# Altium schematic sheet Monitoring.SchDoc
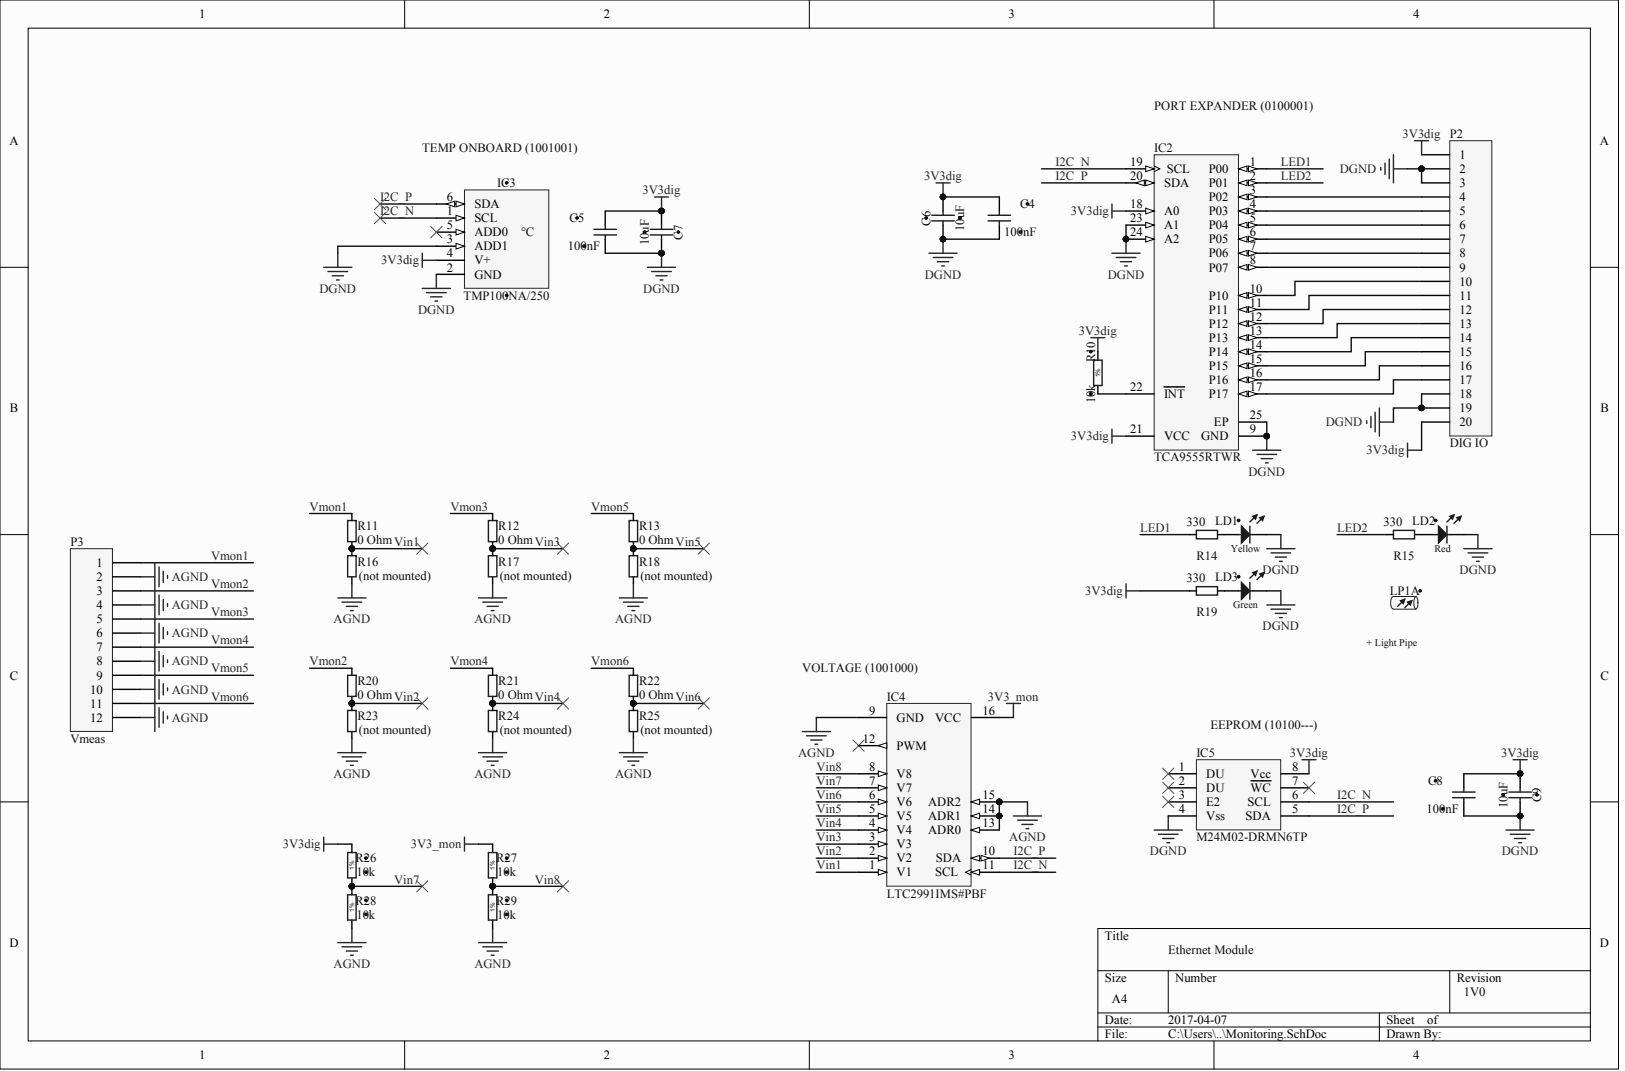
[diagram p0: Monitoring.SchDoc]

## Parts
C4  100nF  [CAPC1005X55N]
C5  100nF  [CAPC1005X55N]
C6  10uF  [CAPC1608X87N]
C7  10uF  [CAPC1608X87N]
C8  100nF  [CAPC1005X55N]
C9  10uF  [CAPC1608X87N]
IC2  TCA9555RTWR
IC3  TMP100NA/250  [SOT95P280X145-6N]
IC4  LTC2991IMS#PBF
IC5  M24M02-DRMN6TP
LD1  Yellow  [LED_DIALIGHT_597-3401-507F]
LD2  Red  [LED_DIALIGHT_597-3111-507F]
LD3  Green  [LED_DIALIGHT_597-3301-507F]
LP1A  =Color  [LEDLP_DIALIGHT_515-1064F]
P2  DIG IO
P3  Vmeas
R10  10k  [RESC1005X40N]
R11  Resistance (Ohms): 0 Ohm  (hidden comment: ERJ-2RKF2201X)
R12  Resistance (Ohms): 0 Ohm  (hidden comment: ERJ-2RKF2201X)
R13  Resistance (Ohms): 0 Ohm  (hidden comment: ERJ-2RKF2201X)
R14  ERJ-2RKF2201X  (value not drawn)
R15  ERJ-2RKF2201X  (value not drawn)
R16  ERJ-2RKF2201X  (value not drawn)
R17  ERJ-2RKF2201X  (value not drawn)
R18  ERJ-2RKF2201X  (value not drawn)
R19  ERJ-2RKF2201X  (value not drawn)
R20  Resistance (Ohms): 0 Ohm  (hidden comment: ERJ-2RKF2201X)
R21  Resistance (Ohms): 0 Ohm  (hidden comment: ERJ-2RKF2201X)
R22  Resistance (Ohms): 0 Ohm  (hidden comment: ERJ-2RKF2201X)
R23  ERJ-2RKF2201X  (value not drawn)
R24  ERJ-2RKF2201X  (value not drawn)
R25  ERJ-2RKF2201X  (value not drawn)
R26  10k  [RESC1005X40N]
R27  10k  [RESC1005X40N]
R28  10k  [RESC1005X40N]
R29  10k  [RESC1005X40N]

## Nets
3V3_MON: IC4.16(VCC) R27.2
3V3DIG: C4.2 C5.2 C6.2 C7.2 C8.2 C9.2 IC2.18(A0) IC2.21(VCC) IC3.4(V+) IC5.8(Vcc) P2.1 P2.20 R10.2 R19.1 R26.2
AGND: IC4.9(GND) IC4.13(ADR0) IC4.14(ADR1) IC4.15(ADR2) P3.2 P3.4 P3.6 P3.8 P3.10 P3.12 R16.2 R17.2 R18.2 R23.2 R24.2 R25.2 R28.1 R29.1
DGND: C4.1 C5.1 C6.1 C7.1 C8.1 C9.1 IC2.9(GND) IC2.23(A1) IC2.24(A2) IC2.25(EP) IC3.2(GND) IC3.3(ADD1) IC5.4(Vss) LD1.1 LD2.1 LD3.1 P2.2 P2.3 P2.18 P2.19
I2C_N: IC2.19(SCL) IC3.1(SCL) IC4.11(SCL) IC5.6(SCL)
I2C_P: IC2.20(SDA) IC3.6(SDA) IC4.10(SDA) IC5.5(SDA)
LED1: IC2.1(P00) R14.1
LED2: IC2.2(P01) R15.1
VIN1: IC4.1(V1) R11.2 R16.1
VIN2: IC4.2(V2) R20.2 R23.1
VIN3: IC4.3(V3) R12.2 R17.1
VIN4: IC4.4(V4) R21.2 R24.1
VIN5: IC4.5(V5) R13.2 R18.1
VIN6: IC4.6(V6) R22.2 R25.1
VIN7: IC4.7(V7) R26.1 R28.2
VIN8: IC4.8(V8) R27.1 R29.2
VMON1: P3.1 R11.1
VMON2: P3.3 R20.1
VMON3: P3.5 R12.1
VMON4: P3.7 R21.1
VMON5: P3.9 R13.1
VMON6: P3.11 R22.1
NetIC2_3: IC2.3(P02) P2.4
NetIC2_4: IC2.4(P03) P2.5
NetIC2_5: IC2.5(P04) P2.6
NetIC2_6: IC2.6(P05) P2.7
NetIC2_7: IC2.7(P06) P2.8
NetIC2_8: IC2.8(P07) P2.9
NetIC2_10: IC2.10(P10) P2.10
NetIC2_11: IC2.11(P11) P2.11
NetIC2_12: IC2.12(P12) P2.12
NetIC2_13: IC2.13(P13) P2.13
NetIC2_14: IC2.14(P14) P2.14
NetIC2_15: IC2.15(P15) P2.15
NetIC2_16: IC2.16(P16) P2.16
NetIC2_17: IC2.17(P17) P2.17
NetIC2_22: IC2.22(INT) R10.1
NetLD1_2: LD1.2 R14.2
NetLD2_2: LD2.2 R15.2
NetLD3_2: LD3.2 R19.2

Not connected: IC3 5(ADD0); IC4 12(PWM); IC5 1(DU) 2(DU) 3(E2) 7(WC)

## Library data of the parts
C4 C5 C8 = (no symbol name): Part Number: CC0402_100NF_16V_10%_X7R; Part Description: SMD Multilayer Chip Ceramic Capacitor; Manufacturer: GENERIC; Manufacturer1 Part Number: GRM155R71C104KA88D; Voltage: 16V; TC: X7R; Tolerance: ±10%; Table: Capacitors SMD; Component Kind: Standard; Component Type: Standard; Pin Count: 2; Value: 100nF; Manufacturer Part Number: CC0402_100NF_16V_10%_X7R; Case: 0402; Mounted: Yes; Socket: No; SMD: Yes; Manufacturer1 Example: MURATA; Manufacturer1 ComponentHeight: 0.55mm; HelpURL: \\cern.ch\dfs\Applications\Altium\Datasheets\CC0402_X7R_MURATA_GRM.pdf; CreateDate: 05/12/2013; LatestRevisionDate: 03/12/2007; DigiKey part no.: 490-3261-1-ND; Author: CERN DEM JLC
C6 C7 C9 = (no symbol name): Part Number: CC0603_10UF_6.3V_20%_X5R; Part Description: SMD Multilayer Chip Ceramic Capacitor; Manufacturer: GENERIC; Manufacturer Part Number: C0603C106M9PACTU; Voltage: 6.3V; TC: X5R; Tolerance: ±20%; Table: Capacitors SMD; Component Kind: Standard; Component Type: Standard; Pin Count: 2; Value: 10uF; Case: 0603; Mounted: Yes; Socket: No; SMD: Yes; Manufacturer1 Example: KEMET; Manufacturer1 ComponentHeight: 0.95mm; HelpURL: \\cern.ch\dfs\Applications\Altium\Datasheets\CC0603_X5R_KEMET.pdf; CreateDate: 03/12/2007; LatestRevisionDate: 03/12/2007; DigiKey part no.: 399-5504-1-ND; Author: CERN DEM JLC
IC2 = (no symbol name): Manufacturer: Texas Instruments; Eco-Plan: Green (RoHS & no Sb/Br); SubFamily: I2C IO Expander; Interrupt Output: Yes; Max Frequency(kHz): 400; I2C Address: 0100 xxx; # of I/Os: 16; Vcc range(V): 1.65 to 5.5; DatasheetVersion: SCPS200A; PackageVersion: Jul-2004; PackageDescription: 24-Lead Plastic Quad FlatPack, No-Lead, 4x4x0.8mm Body [WQFN]; Supplier 1: Digi-Key; Supplier Part Number 1: 296-24857-2-ND; Supplier 2: Digi-Key; Supplier Part Number 2: 296-24857-1-ND; Supplier 3: Digi-Key; Supplier Part Number 3: 296-24857-6-ND; Supplier 4: Newark; Supplier Part Number 4: 24R9979; Supplier 5: Mouser; Supplier Part Number 5: 595-TCA9555RTWR; Configuration Registers: Yes; 5V Tolerant I/O: Yes; Push-Pull I/O Type: Yes; Mounting Technology: Surface Mount; PartNumber: TCA9555RTWR; Code_JEDEC: MO-220; ComponentLink1Description: Datasheet; ComponentLink1URL: http://www.ti.com/general/docs/lit/getliterature.tsp?genericPartNumber=TCA9555&fileType=pdf; DigiKey part no.: 296-24857-2-ND; Manufacturer part no.: TCA9555RTWR
IC3 = (no symbol name): Part Description: -55°C to +125°C, ±3.0°C  Accuracy, Digital Temperature Sensor with I2C Interface; Manufacturer: TEXAS INSTRUMENTS; Table: Sensors; Part Number: TMP100NA/250; Component Kind: Standard; Component Type: Standard; Device: TMP100NA/250; PackageDescription: SOT23, 6-Leads, Body 1.6x3.0mm, Pitch 0.95mm, IPC Medium Density; Manufacturer Part Number: TMP100NA/250; Pin Count: 6; Case: SOT23-6; Mounted: Yes; Socket: No; SMD: Yes; ComponentHeight: 1.45mm; HelpURL: \\cern.ch\dfs\Applications\Altium\Datasheets\TMP100NA-250.pdf; CreateDate: 29/10/2012; LatestRevisionDate: 29/10/2012; DigiKey part no.: 296-12749-1-ND; Author: CERN DEM JLC
IC4 = (no symbol name): Manufacturer: Linear Technology; DatasheetVersion: Rev. B; PackageVersion: Rev. Ø, 06/2010; PackageReference: MS16; PackageDescription: 16-Pin Plastic MSOP, Body 4.04 x 3.00 mm, Pitch 0.50 mm; Supplier 1: Digi-Key; Supplier Part Number 1: LTC2991IMS#PBF-ND; Supplier 2: Farnell; Supplier Part Number 2: 2308601; Supplier 3: Newark; Supplier Part Number 3: 59T5577; RoHS: TRUE; Mounting Technology: Surface Mount; PartNumber: LTC2991IMS#PBF; ComponentLink1Description: Manufacturer URL; ComponentLink1URL: http://www.linear.com/; ComponentLink2Description: Datasheet; ComponentLink2URL: http://cds.linear.com/docs/en/datasheet/2991fb.pdf; ComponentLink3Description: Package Specification; ComponentLink3URL: http://cds.linear.com/docs/en/packaging/05081669_A_MS16.pdf; DigiKey part no.: LTC2991IMS#PBF-ND
IC5 = (no symbol name): Manufacturer: STMicroelectronics; Format - Memory: EEPROMs - Serial; Memory Type: EEPROM; Memory Size: 2M (256K x 8); Speed: 100kHz, 400kHz, 1MHz; Interface: I²C, 2-Wire Serial; Voltage - Supply: 1.8 V ~ 5.5 V; Supplier 1: Digi-Key; Manufacturer Part Number: M24M02-DRMN6TP; RoHS: RoHS Compliant; Category: Integrated Circuits (ICs); Stock 1: 5255; Pricing 1: 1=2.46, 10=2.211, 25=2.1756, 50=2.1692, 100=1.938, 250=1.87548, 500=1.86808, 1000=1.73979 (EUR); Packaging: Cut Tape (CT); Operating Temperature: -40°C ~ 85°C (TA); Package / Case: 8-SOIC (0.154", 3.90mm Width); Supplier Device Package: 8-SO; ComponentLink1URL: http://www.st.com/content/ccc/resource/technical/document/datasheet/b7/de/9b/f6/03/28/4e/8e/CD00290537.pdf/files/CD00290537.pdf/jcr:content/translations/en.CD00290537.pdf; ComponentLink1Description: http://www.st.com/content/ccc/resource/technical/document/datasheet/b7/de/9b/f6/03/28/4e/8e/CD00290537.pdf/files/CD00290537.pdf/jcr:content/translations/en.CD00290537.pdf; Supplier Part Number 1: 497-11600-1-ND; DigiKey part no.: 497-11600-1-ND
LD1 = (no symbol name): Part Description: SMT Yellow LED, 597 Serie; Manufacturer: DIALIGHT; Manufacturer Part Number: 597-3401-507F; Table: LEDs & Displays; Part Number: LED_DIALIGHT_597-3401-507F; Component Kind: Standard; Component Type: Standard; Color: Yellow; PackageDescription: SMT Yellow LED,Body 3x1.6mm (1208),  DIALIGHT 597 Serie; Pin Count: 2; Mounted: Yes; Socket: No; SMD: Yes; ComponentHeight: 1.5mm; HelpURL: \\cern.ch\dfs\Applications\Altium\Datasheets\LED_DIALIGHT_597-3401-507F.pdf; CreateDate: 2012-10-11; LatestRevisionDate: 2013-03-07; DigiKey part no.: 350-2435-1-ND; Author: CERN DEM JLC
LD2 = (no symbol name): Part Description: SMT AlGaAs Red LED, 597 Serie; Manufacturer: DIALIGHT; Manufacturer Part Number: 597-3111-507F; Table: LEDs & Displays; Part Number: LED_DIALIGHT_597-3111-507F; Component Kind: Standard; Component Type: Standard; Color: Red; PackageDescription: SMT AlGaAs Red LED,Body 3x1.6mm (1208),  DIALIGHT 597 Serie; Pin Count: 2; Mounted: Yes; Socket: No; SMD: Yes; ComponentHeight: 1.5mm; HelpURL: \\cern.ch\dfs\Applications\Altium\Datasheets\LED_DIALIGHT_597-3111-507F.pdf; CreateDate: 2011-10-26; LatestRevisionDate: 2011-10-26; DigiKey part no.: 350-2433-1-ND; Author: CERN DEM JLC
LD3 = (no symbol name): Part Description: SMT Green LED, 597 Serie; Manufacturer: DIALIGHT; Manufacturer Part Number: 597-3301-507F; Table: LEDs & Displays; Part Number: LED_DIALIGHT_597-3301-507F; Component Kind: Standard; Component Type: Standard; Color: Green; PackageDescription: SMT Green LED,Body 3x1.6mm (1208),  DIALIGHT 597 Serie; Pin Count: 2; Mounted: Yes; Socket: No; SMD: Yes; ComponentHeight: 1.5mm; HelpURL: \\cern.ch\dfs\Applications\Altium\Datasheets\LED_DIALIGHT_597-3301-507F.pdf; CreateDate: 2013-03-07; LatestRevisionDate: 2013-03-07; DigiKey part no.: 350-2434-1-ND; Author: CERN DEM JLC
LP1A = (no symbol name): Part Description: Right Angle Tri-Level 2.5mm Round Light Pipe; Manufacturer: DIALIGHT; Manufacturer Part Number: 515-1064F; Table: LEDs & Displays; Part Number: LEDLP_DIALIGHT_515-1064F; Component Kind: Standard; Component Type: Standard; PackageDescription: Right Angle Tri-Level 2.5mm Round Light Pipe, Body 22.9 x5.22mm; Pin Count: 0; Mounted: Yes; Socket: No; SMD: No; ComponentHeight: 13.7mm; HelpURL: \\cern.ch\dfs\Applications\Altium\Datasheets\LEDLP_DIALIGHT_515-1064F.pdf; CreateDate: 2011-10-26; LatestRevisionDate: 2011-10-26; DigiKey part no.: 350-2181-ND; Author: CERN DEM JLC
R10 R26-R29 = (no symbol name): Part Description: General Purpose Thick Film Chip Resistor; Manufacturer: GENERIC; Manufacturer Part Number: RC0402FR-0710KL; Power: 0.0625W; TC: ±100ppm/°C; Tolerance: ±1%; Table: Resistors SMD; Component Kind: Standard; Component Type: Standard; Pin Count: 2; Value: 10k; Case: 0402; Mounted: Yes; SMD: Yes; Manufacturer1 Example: YAGEO PHYCOMP; CreateDate: 2007-12-03; DigiKey part no.: 311-10.0KLRCT-ND; Author: CERN DEM JLC
R11-R13 R20-R22 = (no symbol name): Manufacturer Part Number: RC0805JR-070RL; Manufacturer: GENERIC; Tolerance: ±1%; Power (Watts): 0.125W; Temperature Coefficient: ±100ppm/°C; Supplier 1: Digi-Key; Manufacturer example: Yageo; RoHS: RoHS Compliant; Category: Resistors; Pricing 1: 1=0.1, 50=0.015, 100=0.011, 250=0.0084, 500=0.00674, 1000=0.00496, 5000=0.00355 (USD); Packaging: Cut Tape (CT); Composition: Thick Film; Operating Temperature: -55°C ~ 155°C; Package / Case: 0805 (2012 Metric); Supplier Device Package: 0805; Size / Dimension: 2.00mm x 1.2mm; Number of Terminations: 2; DigiKey part no.: 311-0.0ARCT-ND
R14 R15 R19 = (no symbol name): Manufacturer Part Number: 311-330ARTR-ND; Manufacturer: GENERIC; Tolerance: ±1%; Power (Watts): 0.125W; Temperature Coefficient: ±100ppm/°C; Resistance (Ohms): 330; Mounted:: mounted; Supplier 1: Digi-Key; Manufacturer example: Yageo; RoHS: RoHS Compliant; Category: Resistors; Pricing 1: 1=0.1, 50=0.015, 100=0.011, 250=0.0084, 500=0.00674, 1000=0.00496, 5000=0.00355 (USD); Packaging: Cut Tape (CT); Composition: Thick Film; Operating Temperature: -55°C ~ 155°C; Package / Case: 0805 (2012 Metric); Supplier Device Package: 0805; Size / Dimension: 2.00mm x 1.2mm; Number of Terminations: 2; DigiKey part no.: 311-330ARTR-ND
R16-R18 R23-R25 = (no symbol name): Manufacturer Part Number: RC0805FR-0710KL; Manufacturer: GENERIC; Tolerance: ±1%; Power (Watts): 0.125W; Temperature Coefficient: ±100ppm/°C; Resistance (Ohms): 10K; Mounted:: (not mounted); Supplier 1: Digi-Key; Manufacturer example: Yageo; RoHS: RoHS Compliant; Category: Resistors; Pricing 1: 1=0.1, 50=0.015, 100=0.011, 250=0.0084, 500=0.00674, 1000=0.00496, 5000=0.00355 (USD); Packaging: Cut Tape (CT); Composition: Thick Film; Operating Temperature: -55°C ~ 155°C; Package / Case: 0805 (2012 Metric); Supplier Device Package: 0805; Size / Dimension: 2.00mm x 1.2mm; Number of Terminations: 2; DigiKey part no.: 311-10.0KCRCT-ND
P2 P3 = (no symbol name): LatestRevisionDate: 17-Jul-2002; LatestRevisionNote: Re-released for DXP Platform.; Publisher: Altium Limited
  P2: DigiKey part no.: 277-1281-ND
  P3: DigiKey part no.: 277-1283-ND
